# Altium schematic sheet Interface.SchDoc(Interface)
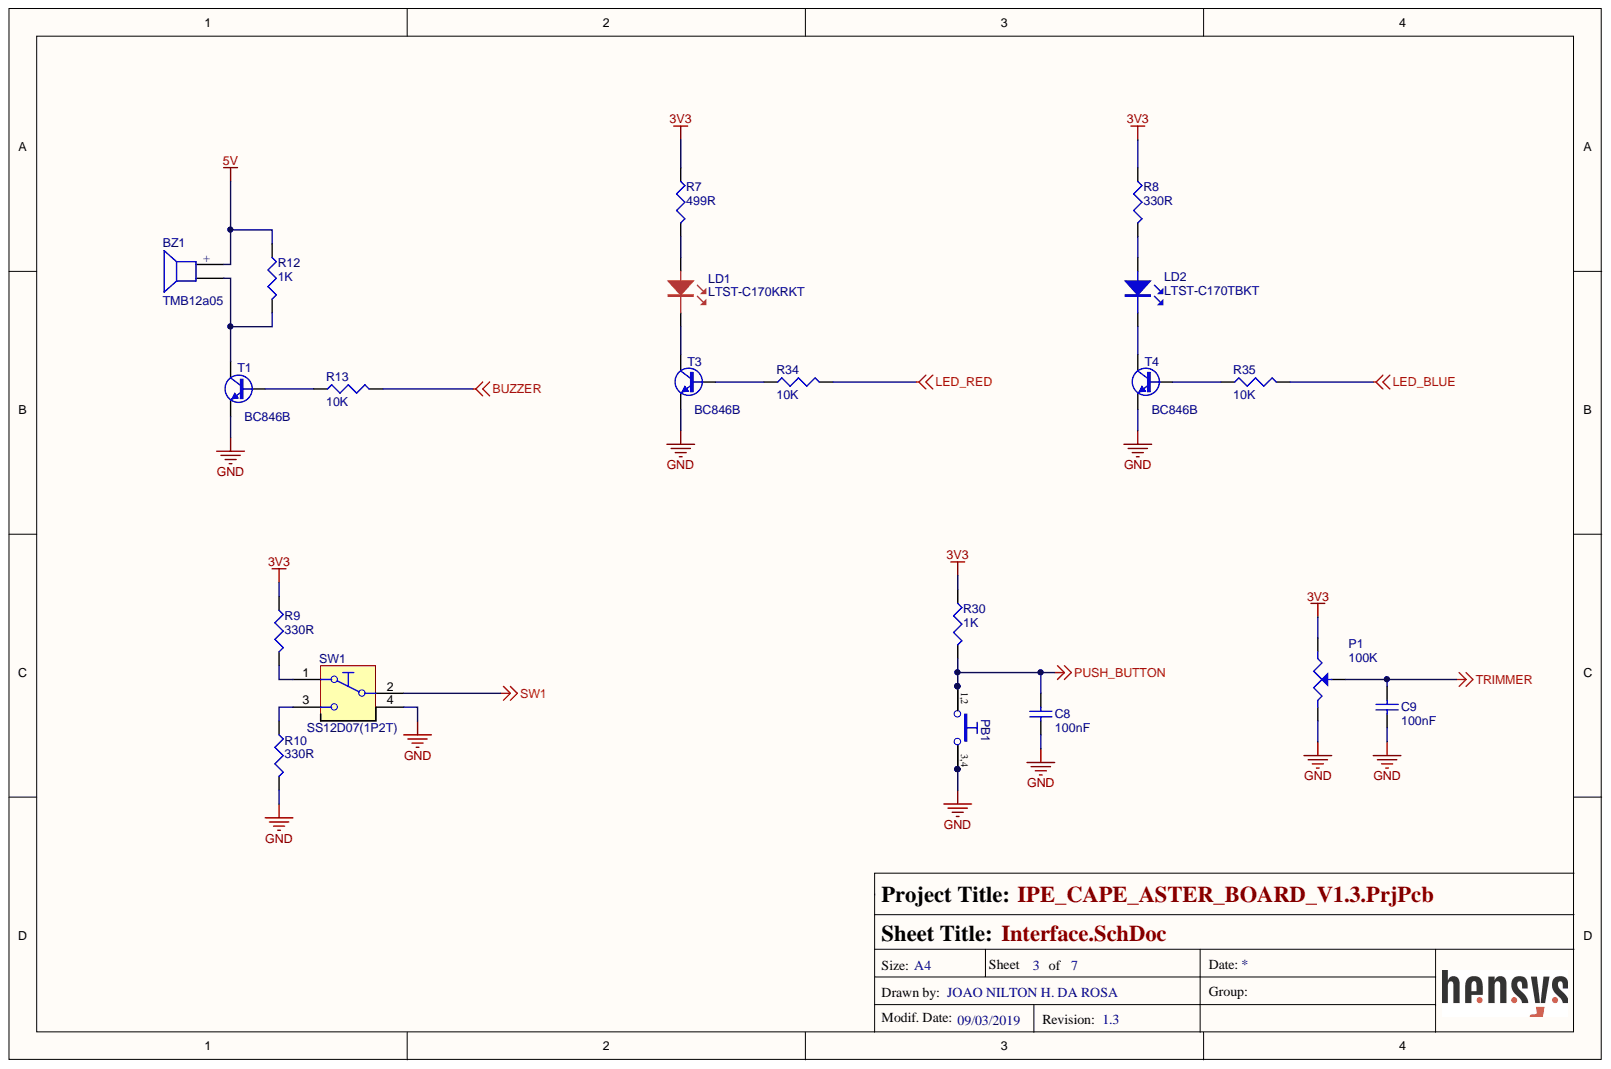
[diagram p0: Interface.SchDoc]

## Parts
BZ1  TMB12a05  [buzzer12mm]
C8  100nF  [0402 cap]
C9  100nF  [0402 cap]
LD1  LTST-C170KRKT  [0805_LED]
LD2  LTST-C170TBKT  [0805_LED]
P1  100K  [TRIMPOT-3386Y]
PB1  Push Button  [PB_TYCO_FSM2JSMA]  (value not drawn)
R7  499R  [0603 res]
R8  330R  [0603 res]
R9  330R  [0603 res]
R10  330R  [0603 res]
R12  1K  [0603 res]
R13  10K  [0402 res]
R30  1K  [0402 res]
R34  10K  [0402 res]
R35  10K  [0402 res]
SW1  SS12D07(1P2T)  [SS12D07]
T1  BC846B  [SOT95P230X110-3N]
T3  BC846B  [SOT95P230X110-3N]
T4  BC846B  [SOT95P230X110-3N]

## Nets
3V3: P1.3 R7.1 R8.1 R9.1 R30.1
5V: BZ1.1 R12.1
BUZZER: R13.2
GND: C8.1 C9.1 P1.1 PB1.3 PB1.4 R10.2 SW1.4 T1.2 T3.2 T4.2
LED_BLUE: R35.2
LED_RED: R34.2
PUSH_BUTTON: C8.2 PB1.1 PB1.2 R30.2
SW1: SW1.2
TRIMMER: C9.2 P1.2
NetBZ1_2: BZ1.2 R12.2 T1.3
NetLD1_1: LD1.1 T3.3
NetLD1_2: LD1.2 R7.2
NetLD2_1: LD2.1 T4.3
NetLD2_2: LD2.2 R8.2
NetR9_2: R9.2 SW1.1
NetR10_1: R10.1 SW1.3
NetR13_1: R13.1 T1.1
NetR34_1: R34.1 T3.1
NetR35_1: R35.1 T4.1

## Library data of the parts
BZ1 = CEM-1205C: AUDIO MAGNETIC INDICATOR 4-7V TH; Manufacturer: CUI Inc.; Voltage Range: 4 ~ 7V; Voltage - Rated: 5V; Sound Pressure Level: 85dB @ 5V, 10cm; Ratings: Washable; Port Location: Top; Operating Mode: Single Tone; Input Type: DC; Frequency: 2.3kHz; Driver Circuitry: Indicator, Internally Driven; Current - Supply: 35mA; Termination: PC Pins; Technology: Magnetic; Supplier Part Number 1: 102-1124-ND; Supplier 1: Digi-Key; Stock 1: 33280; Size / Dimension: 0.472" Dia (12.00mm); RoHS: RoHS Compliant; Pricing 1: 1=2.31, 10=1.928, 25=1.542, 50=1.3878, 100=1.2722, 250=1.2336, 500=1.19506, 1000=1.09868, 5000=0.98303 (USD); Part Status: Active; Packaging: Tray; Operating Temperature: -30°C ~ 70°C; Mounting Type: Through Hole; Manufacturer Part Number: CEM-1205C; Library Name: Buzzer.IntLib; Height - Seated (Max): 0.394" (10.00mm); Duration: -; ComponentLink1URL: http://www.cui.com/product/resource/digikeypdf/cem-1205c.pdf; ComponentLink1Description: http://www.cui.com/product/resource/digikeypdf/cem-1205c.pdf; Component Kind: Standard; Category: Audio Products; Approvals: -
C8 C9 = Cap Ceram 0402: CAP CER 0.1UF 16V X7R 0402; Manufacturer Part Number: GCM155R71C104KA55D; Manufacturer: Murata Electronics North America; Tolerance: ±10%; Voltage - Rated: 16V; Thickness (Max): 0.022" (0.55mm); Temperature Coefficient: X7R; Supplier Part Number 1: 490-4759-1-ND; Supplier 1: Digi-Key; Stock 1: 918618; Size / Dimension: 0.039" L x 0.020" W (1.00mm x 0.50mm); RoHS: RoHS Compliant; Ratings: AEC-Q200; Pricing 1: 1=0.1, 10=0.039, 100=0.0174, 500=0.01244, 1000=0.00977, 2500=0.00888, 5000=0.00817 (USD); Part Status: Active; Packaging: Cut Tape (CT); Package / Case: 0402 (1005 Metric); Operating Temperature: -55°C ~ 125°C; Mounting Type: Surface Mount, MLCC; Lead Style: -; Lead Spacing: -; Height - Seated (Max): -; Features: -; ComponentLink1URL: http://psearch.en.murata.com/capacitor/product/GCM155R71C104KA55#.pdf; ComponentLink1Description: http://psearch.en.murata.com/capacitor/product/GCM155R71C104KA55#.pdf; Component Kind: Standard; Category: Capacitors; Applications: Automotive; Library Name: Passivos.IntLib
LD1 = LTST-C170KRKT: LED SUPER RED CLEAR 0805 SMD; Manufacturer: Lite-On Inc; Wavelength - Peak: 639nm; Wavelength - Dominant: 631nm; Voltage - Forward (Vf) (Typ): 2V; Viewing Angle: 130°; Millicandela Rating: 54mcd; Lens Style/Size: Rectangle with Flat Top, 1.4mm x 1.25mm; Current - Test: 20mA; Supplier Part Number 2: 160-1415-1-ND; Supplier Part Number 1: 160-1415-6-ND; Supplier 2: Digi-Key; Supplier 1: Digi-Key; Stock 2: 349195; Size / Dimension: 2.00mm L x 1.25mm W; RoHS: RoHS Compliant; Pricing 2: 1=0.37, 10=0.287, 100=0.1566, 250=0.1044, 500=0.08874, 1000=0.06786 (USD); Packaging: Cut Tape (CT); Package / Case: 0805 (2012 Metric); Mounting Type: Surface Mount; Manufacturer Part Number: LTST-C170KRKT; Luminous Flux @ Current - Test: -; Library Name: Leds.IntLib; Lens Type: Clear; Height: 1.10mm; ComponentLink1URL: http://optoelectronics.liteon.com/upload/download/DS-22-99-0150/S_110_LTST-C170KRKT.pdf; ComponentLink1Description: http://optoelectronics.liteon.com/upload/download/DS-22-99-0150/S_110_LTST-C170KRKT.pdf; Component Kind: Standard; Color: Red; Category: Optoelectronics
LD2 = LTST-C170TBKT: LED BLUE CLEAR 0805 SMD; Manufacturer: Lite-On Inc.; Wavelength - Peak: 468nm; Wavelength - Dominant: 470nm; Voltage - Forward (Vf) (Typ): 3.3V; Viewing Angle: 130°; Millicandela Rating: 104mcd; Lens Style/Size: Rectangle with Flat Top, 1.40mm x 1.25mm; Lens Color: Colorless; Current - Test: 20mA; Supplier Part Number 1: 160-1579-6-ND; Supplier 1: Digi-Key; Stock 1: 106201; Size / Dimension: 2.00mm L x 1.25mm W; RoHS: RoHS Compliant; Pricing 1: 1=0.43, 10=0.303, 25=0.2624, 100=0.202, 250=0.16408, 500=0.12118, 1000=0.10098 (USD); Part Status: Active; Packaging: Digi-Reel®; Package / Case: 0805 (2012 Metric); Mounting Type: Surface Mount; Manufacturer Part Number: LTST-C170TBKT; Library Name: Leds.IntLib; Lens Transparency: Clear; Height (Max): 1.10mm; Features: -; ComponentLink1URL: http://optoelectronics.liteon.com/upload/download/DS-22-99-0226/LTST-C170TBKT.pdf; ComponentLink1Description: http://optoelectronics.liteon.com/upload/download/DS-22-99-0226/LTST-C170TBKT.pdf; Component Kind: Standard; Color: Blue; Category: Optoelectronics
P1 = 3386F-1-104TLF: TRIMMER 100K OHM 0.5W PC PIN; Manufacturer: Bourns Inc.; Tolerance: ±10%; Temperature Coefficient: ±100ppm/°C; Resistive Material: Cermet; Power (Watts): 0.5W, 1/2W; Adjustment Type: Top Adjustment; Termination Style: PC Pins; Supplier Part Number 1: 3386F-1-104TLF-ND; Supplier 1: Digi-Key; Stock 1: 66; Size / Dimension: Square - 0.375" x 0.375" Face x 0.190" H (9.53mm x 9.53mm x 4.83mm); RoHS: RoHS Compliant; Pricing 1: 1=2.48, 10=2.175, 25=1.95, 50=1.875, 100=1.8, 250=1.725, 500=1.425, 1000=1.35, 5000=1.125 (USD); Part Status: Active; Packaging: Tube; Mounting Type: Through Hole; Manufacturer Part Number: 3386F-1-104TLF; Library Name: Potentiometer.IntLib; ComponentLink1URL: http://www.bourns.com/docs/Product-Datasheets/3386.pdf; ComponentLink1Description: http://www.bourns.com/docs/Product-Datasheets/3386.pdf; Component Kind: Standard; Category: Potentiometers, Variable Resistors
PB1 = PB_TYCO_FSM2JSMA: Push-Button Switch SPDT; Part Description: 12VDC 50mA SPST Tactile  Push-Button Switch; Manufacturer Part Number: FSM2JSMA or  2-1437565-7; Manufacturer: TYCO ELECTRONICS; Table: Switches; Status: None; Socket: No; SMD: Yes; Pin Count: 4; Part Number: PB_TYCO_FSM2JSMA; PackageDescription: Body 6mm x 6mm, Surface Mount Tactile Switches, TYCO ALCOSWITCH FSMJSMA Series; Mounted: Yes; Library Name: Eletro-mechanical.DbLib; LatestRevisionDate: 21/06/2012; HelpURL: \\cern.ch\dfs\Applications\Altium\Datasheets\PB_TYCO_FSM2JSMA.pdf; Family: Push Button; Database Table Name: Switches; CreateDate: 08/09/2008; Component Type: Standard; Component Kind: Standard; ComponentHeight: 4.59mm; Author: CERN DEM JLC
R7 = Resistor 0603: RES SMD 499 OHM 1% 1/10W 0603; Manufacturer Part Number: RC1608F331CS; Manufacturer: Samsung Electro-Mechanics; Temperature Coefficient: ±100ppm/°C; Power (Watts): 0.1W, 1/10W; Tolerance: ±1%; Supplier Part Number 1: 1276-4604-6-ND; Supplier Device Package: 0603; Supplier 1: Digi-Key; Stock 1: 312119; Size / Dimension: 0.063" L x 0.032" W (1.60mm x 0.80mm); RoHS: RoHS Compliant; Pricing 1: 1=0.1, 10=0.025, 100=0.0101, 1000=0.00452, 2500=0.00392 (USD); Part Status: Active; Packaging: Digi-Reel®; Package / Case: 0603 (1608 Metric); Operating Temperature: -55°C ~ 155°C; Height - Seated (Max): 0.022" (0.55mm); Features: Moisture Resistant; Failure Rate: -; Composition: Thick Film; ComponentLink1URL: http://www.samsungsem.com/kr/support/product-search/chip-resistor/RC1608F331CS.jsp; ComponentLink1Description: http://www.samsungsem.com/kr/support/product-search/chip-resistor/RC1608F331CS.jsp; Component Kind: Standard; Category: Resistors; Library Name: Passivos.IntLib
R8-R10 = Resistor 0603: RES SMD 330 OHM 1% 1/10W 0603; Manufacturer Part Number: RC1608F331CS; Manufacturer: Samsung Electro-Mechanics; Temperature Coefficient: ±100ppm/°C; Power (Watts): 0.1W, 1/10W; Tolerance: ±1%; Supplier Part Number 1: 1276-4604-6-ND; Supplier Device Package: 0603; Supplier 1: Digi-Key; Stock 1: 312119; Size / Dimension: 0.063" L x 0.032" W (1.60mm x 0.80mm); RoHS: RoHS Compliant; Pricing 1: 1=0.1, 10=0.025, 100=0.0101, 1000=0.00452, 2500=0.00392 (USD); Part Status: Active; Packaging: Digi-Reel®; Package / Case: 0603 (1608 Metric); Operating Temperature: -55°C ~ 155°C; Height - Seated (Max): 0.022" (0.55mm); Features: Moisture Resistant; Failure Rate: -; Composition: Thick Film; ComponentLink1URL: http://www.samsungsem.com/kr/support/product-search/chip-resistor/RC1608F331CS.jsp; ComponentLink1Description: http://www.samsungsem.com/kr/support/product-search/chip-resistor/RC1608F331CS.jsp; Component Kind: Standard; Category: Resistors; Library Name: Passivos.IntLib
R12 = Resistor 0603: Component Kind: Standard; Library Name: IPE_CAPE_ASTER_BOARD_V1.0.IntLib
R13 R34 R35 = Resistor 0402: RES SMD 10K OHM 5% 1/16W 0402; Manufacturer Part Number: RC1005J103CS; Manufacturer: Samsung Electro-Mechanics; Temperature Coefficient: ±100ppm/°C; Power (Watts): 0.063W, 1/16W; Tolerance: ±5%; Supplier Part Number 1: 1276-4400-1-ND; Supplier Device Package: 0402; Supplier 1: Digi-Key; Stock 1: 523260; Size / Dimension: 0.039" L x 0.020" W (1.00mm x 0.50mm); RoHS: RoHS Compliant; Pricing 1: 1=0.1, 10=0.011, 100=0.0046, 1000=0.00207, 2500=0.0018, 5000=0.00148 (USD); Part Status: Active; Packaging: Cut Tape (CT); Package / Case: 0402 (1005 Metric); Operating Temperature: -55°C ~ 155°C; Height - Seated (Max): 0.016" (0.40mm); Features: Moisture Resistant; Failure Rate: -; Composition: Thick Film; ComponentLink1URL: http://www.samsungsem.com/kr/support/product-search/chip-resistor/RC1005J103CS.jsp; ComponentLink1Description: http://www.samsungsem.com/kr/support/product-search/chip-resistor/RC1005J103CS.jsp; Component Kind: Standard; Category: Resistors; Library Name: Passivos.IntLib
R30 = Resistor 0402: RES SMD 1K OHM 5% 1/16W 0402; Manufacturer Part Number: ERJ-2GEJ102X; Manufacturer: Panasonic; Working Voltage: 50V; Temperature Coefficient: 200ppm/°C; Resistance: 1kR; Power Rating: 100mW; Width: 0.02inch; Voltage Rating (DC): 50V; Voltage Rating: 50V; Tolerance: 5%; Termination: SMD/SMT; Supplier Part Number 1: P1.0KJCT-ND; Supplier 1: Digi-Key; Stock 1: 11498946; REACH SVHC: No SVHC; Radiation Hardening: No; Pricing 1: 1=0.1, 10=0.031, 100=0.0125, 1000=0.0056, 2500=0.00486, 5000=0.00401 (USD); Packaging: Tape and Reel; Package Quantity: 1; Number of Terminations: 2; Mount: Surface Mount; Min Operating Temperature: -55°C; Military Standard: Not; Max Power Dissipation: 100mW; Max Operating Temperature: 155°C; Length: 1mm; Lead Free: Lead Free; Height: 0.35mm; Features: Automotive AEC-Q200; Depth: 0.5mm; Contact Plating: Tin; Composition: Thick Film; ComponentLink1URL: http://www.samsungsem.com/kr/support/product-search/chip-resistor/RC1005J103CS.jsp; ComponentLink1Description: http://www.samsungsem.com/kr/support/product-search/chip-resistor/RC1005J103CS.jsp; Component Kind: Standard; Case Code (Metric): 1005; Case Code (Imperial): 0402; Case/Package: 0402; Library Name: IPE_CAPE_ASTER_BOARD_V1.0.IntLib
SW1 = SS12D07(1P2T): CHAVE HH MICRO 3T PCI C/ ALAVANCA; Library Name: Switch.IntLib; Component Kind: Standard
T1 T3 T4 = BC846B: NPN Transistor; Part Description: 65 V 100mA NPN general-purpose transistors; Manufacturer: NXP SEMICONDUCTORS; Table: Transistors; Socket: No; SMD: Yes; Pin Count: 3; Part Number: BC846B; PackageDescription: SOT23, 3 pin, Pitch 0.95mm - 1.3mm W X 2.9mm L X 1.1mm H, IPC Medium Density; Mounted: Yes; Manufacturer Part Number: BC846B; Library Name: ICs And Semiconductors.DbLib; LatestRevisionDate: 10/03/2011; HelpURL: \\cern.ch\dfs\Applications\Altium\Datasheets\BC846B.pdf; Device: BC846B; Database Table Name: Transistors; CreateDate: 10/03/2011; Component Type: Standard; Component Kind: Standard; ComponentHeight: 1.1mm; Case: SOT23-3; Author: CERN DEM JLC
